# Altium schematic sheet 5.04.51805A02_GDMCU.SchDoc(5.04.51805A02_GDMCU)
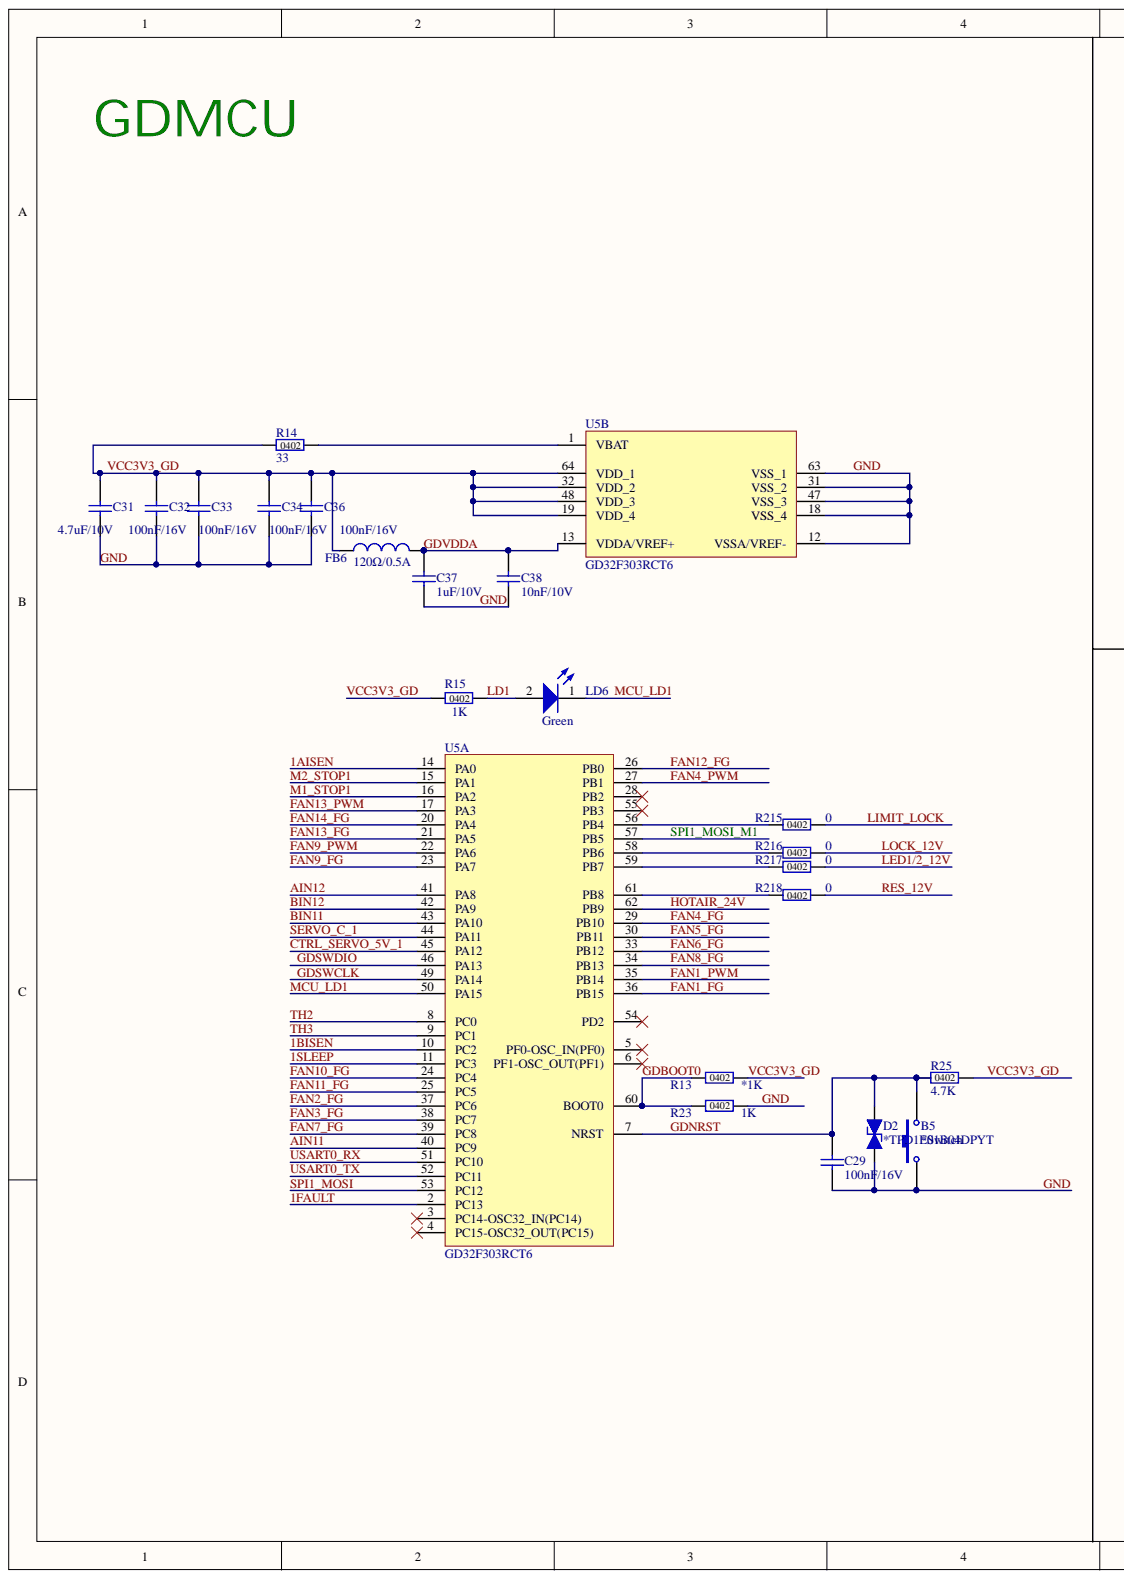
[diagram p0: 5.04.51805A02_GDMCU.SchDoc, left]
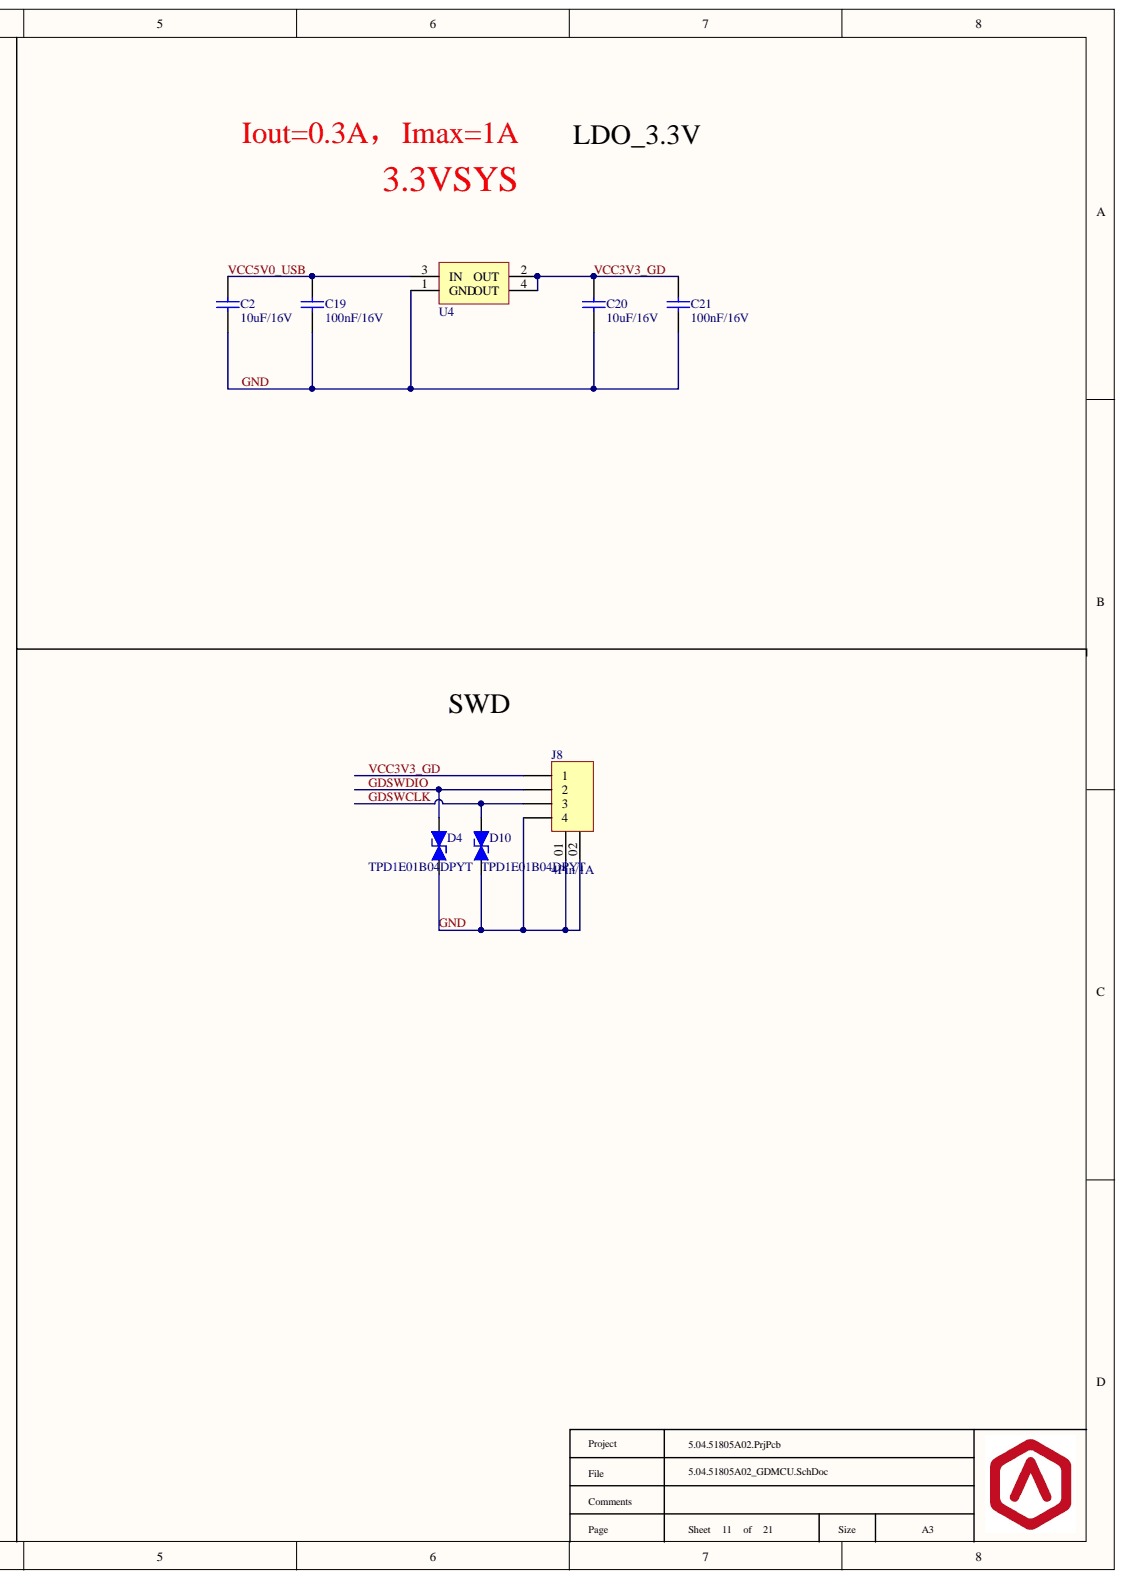
[diagram p1: 5.04.51805A02_GDMCU.SchDoc, right]

## Parts
B5  *Switch  [TS-021]
C2  10uF/16V  [C0603]
C19  100nF/16V  [C0402]
C20  10uF/16V  [C0603]
C21  100nF/16V  [C0402]
C29  100nF/16V  [C0402]
C31  4.7uF/10V  [C0402]
C32  100nF/16V  [C0402]
C33  100nF/16V  [C0402]
C34  100nF/16V  [C0402]
C36  100nF/16V  [C0402]
C37  1uF/10V  [C0402]
C38  10nF/10V  [C0402]
D2  *TPD1E01B04DPYT  [TVS_0402]
D4  TPD1E01B04DPYT  [TVS_0402]
D10  TPD1E01B04DPYT  [TVS_0402]
FB6  120Ω/0.5A  [L0402]
J8  4Pin/1A  [BM04B-GHS-TBT(LF)(SN)]
LD6  Green  [LED0603_2]
R13  *1K  [R0402]
R14  33  [R0402]
R15  1K  [R0402]
R23  1K  [R0402]
R25  4.7K  [R0402]
R215  0  [R0402]
R216  0  [R0402]
R217  0  [R0402]
R218  0  [R0402]
U4  TLV1117LV33DCYR  [SOT-223_L6.7-W3.5-P2.30-BR]  (value not drawn)
U5  GD32F303RCT6  [LQFP-64]  (parts A,B)

## Nets
1AISEN: U5.14(PA0)
1BISEN: U5.10(PC2)
1FAULT: U5.2(PC13)
1SLEEP: U5.11(PC3)
AIN11: U5.40(PC9)
AIN12: U5.41(PA8)
BIN11: U5.43(PA10)
BIN12: U5.42(PA9)
CTRL_SERVO_5V_1: U5.45(PA12)
FAN1_FG: U5.36(PB15)
FAN1_PWM: U5.35(PB14)
FAN2_FG: U5.37(PC6)
FAN3_FG: U5.38(PC7)
FAN4_FG: U5.29(PB10)
FAN4_PWM: U5.27(PB1)
FAN5_FG: U5.30(PB11)
FAN6_FG: U5.33(PB12)
FAN7_FG: U5.39(PC8)
FAN8_FG: U5.34(PB13)
FAN9_FG: U5.23(PA7)
FAN9_PWM: U5.22(PA6)
FAN10_FG: U5.24(PC4)
FAN11_FG: U5.25(PC5)
FAN12_FG: U5.26(PB0)
FAN13_FG: U5.21(PA5)
FAN13_PWM: U5.17(PA3)
FAN14_FG: U5.20(PA4)
GDBOOT0: R13.1 R23.1 U5.60(BOOT0)
GDNRST: B5.2 C29.1 D2.2 R25.1 U5.7(NRST)
GDSWCLK: D10.2 J8.3 U5.49(PA14)
GDSWDIO: D4.2 J8.2 U5.46(PA13)
GDVDDA: C37.2 C38.2 FB6.2 U5.13(VDDA/VREF+)
GND: B5.1 C2.1 C19.1 C20.1 C21.1 C29.2 C31.1 C32.1 C33.1 C34.1 C36.1 C37.1 C38.1 D2.1 D4.1 D10.1 J8.01 J8.02 J8.4 R23.2 U4.1(GND) U5.12(VSSA/VREF-) U5.18(VSS_4) U5.31(VSS_2) U5.47(VSS_3) U5.63(VSS_1)
HOTAIR_24V: U5.62(PB9)
LD1: LD6.2 R15.2
LED1/2_12V: R217.2
LIMIT_LOCK: R215.2
LOCK_12V: R216.2
M1_STOP1: U5.16(PA2)
M2_STOP1: U5.15(PA1)
MCU_LD1: LD6.1 U5.50(PA15)
RES_12V: R218.2
SERVO_C_1: U5.44(PA11)
SPI1_MOSI: U5.53(PC12)
SPI1_MOSI_M1: U5.57(PB5)
TH2: U5.8(PC0)
TH3: U5.9(PC1)
USART0_RX: U5.51(PC10)
USART0_TX: U5.52(PC11)
VCC3V3_GD: C20.2 C21.2 C31.2 C32.2 C33.2 C34.2 C36.2 FB6.1 J8.1 R13.2 R14.1 R15.1 R25.2 U4.2(OUT) U4.4(OUT) U5.19(VDD_4) U5.32(VDD_2) U5.48(VDD_3) U5.64(VDD_1)
VCC5V0_USB: C2.2 C19.2 U4.3(IN)
NetR14_2: R14.2 U5.1(VBAT)
NetR215_1: R215.1 U5.56(PB4)
NetR216_1: R216.1 U5.58(PB6)
NetR217_1: R217.1 U5.59(PB7)
NetR218_1: R218.1 U5.61(PB8)

Not connected: U5 3(PC14-OSC32_IN(PC14)) 4(PC15-OSC32_OUT(PC15)) 5(PF0-OSC_IN(PF0)) 6(PF1-OSC_OUT(PF1)) 28(PB2) 54(PD2) 55(PB3)

## Library data of the parts
B5 = B_TS-021: 开关,TS-021,DC12V50mA,30mOhm,SPST,垂直,SMT,-30°C~70°C; Manufacturer1: 东莞合智电子; Code: 57010003; Component Kind: Standard; ManufacturerPartNumber1: TS-021; Library Name: Raise3D_Switch&Optocoupler.SCHLIB
C2 C20 = C0603_10uF_16V: Ceramic Capacitor, 10uF, ±10%, 16V, -55°C~85°C, C0603; Manufacturer1: SAMSUNG; ManufacturerPartNumber1: CL10A106KO8NQNC; Code: 5172C106; Component Kind: Standard; Library Name: Raise3D_Capacitor.IntLib
C19 C21 C29 C32-C34 C36 = C0402_100nF_16V: Ceramic Capacitor, 100nF, ±10%, 16V, -55°C~125°C, C0402; Manufacturer1: Yageo; ManufacturerPartNumber1: CC0402KPX7R7BB104; Code: 5171C104; Component Kind: Standard; Library Name: Raise3D_Capacitor.IntLib
C31 = C0402_4.7uF_10V: Ceramic Capacitor, 4.7uF, ±20%, 10V, -55°C~85°C, C0402; Manufacturer1: MuRata; ManufacturerPartNumber1: GRM155R61A475MEAAD; Code: 5161C475; Component Kind: Standard; Library Name: Raise3D_Capacitor.IntLib
C37 = C0402_1uF_10V: Ceramic Capacitor, 1uF, ±10%, 10V, -55°C~125°C, C0402; Manufacturer1: Yageo; ManufacturerPartNumber1: CC0402KRX5R6BB105; Code: 5161C105; Component Kind: Standard; Library Name: Raise3D_Capacitor.IntLib
C38 = C0402_10nF_10V: Ceramic Capacitor,10nF,10%,10V,-55°C~125°C,C0402; Manufacturer1: Yageo; ManufacturerPartNumber1: CC0402KRX7R6BB103; Code: 5151C103; Component Kind: Standard; Library Name: Raise3D_Capacitor.IntLib
D2 D4 D10 = TVS_TPD1E01B04DPYT: TVS二极管,TPD1E01B04DPYT,3.6V,1Channel,+/-17kV,+/-15kV,X1SON-2,-40°C~125°C; Manufacturer1: TexasInstruments; Code: 53040002; Component Kind: Standard; ManufacturerPartNumber1: TPD1E01B04DPYT
  D2: Library Name: Raise3D_Diode.SCHLIB
  D4 D10: Library Name: Raise3D_Diode.IntLib
FB6 = Bead_120Ohm_0.5A: Bead,BLM15AG121SN1D,120Ohm,0.5A,25%,L0402,-55°C~125°C; Manufacturer1: Murata; Code: 52010001; Component Kind: Standard; ManufacturerPartNumber1: BLM15AG121SN1D; Library Name: Raise3D_Inductor&Bead.IntLib
J8 = JW_BM04B-GHS-TBT(LF)(SN): 线对板连接器,4PINS,Pitch1.25mm,SMT,OR,1A,象牙白,-25°C~85°C; Manufacturer1: JST; Code: 55010003; Component Kind: Standard; Manufacturer2: 健坤; ManufacturerPartNumber1: BM04B-GHS-TBT(LF)(SN); ManufacturerPartNumber2: A1253AWV-04-F1CB1-P; Library Name: Raise3D_Connector.IntLib
LD6 = LED_APT1608CGCK: LED,APT1608CGCK,Green,2.5V,TOP,LED0603,-40°C~85°C; Manufacturer1: Kingbright; Code: 53010003; Component Kind: Standard; ManufacturerPartNumber1: APT1608CGCK; Library Name: Raise3D_Diode.IntLib
R13 R15 R23 = R0402_1K: Resistor 1Kohm,5%,1/16W,R0402; Manufacturer1: Yageo; ManufacturerPartNumber1: RC0402JR-071KL; Code: 5011001K; Component Kind: Standard; Library Name: Raise3D_Resistor.IntLib
R14 = R0402_33: Resistor 33ohm,1%,1/16W,R0402; Manufacturer1: Yageo; ManufacturerPartNumber1: RC0402FR-0733RL; Code: 5001033R; Component Kind: Standard; Library Name: Raise3D_Resistor.IntLib
R25 = R0402_4.7K: Resistor 4.7Kohm,5%,1/16W,R0402; Manufacturer1: Yageo; ManufacturerPartNumber1: RC0402JR-074K7L; Code: 501104K7; Component Kind: Standard; Manufacturer2: Samsung; ManufacturerPartNumber2: RCS1005J472CS; Library Name: Raise3D_Resistor.IntLib
R215-R218 = R0402_0: Resistor 0ohm,1%,1/16W,R0402; Manufacturer1: Yageo; ManufacturerPartNumber1: RC0402FR-070RL; Code: 5001000R; Component Kind: Standard; Library Name: Raise3D_Resistor.IntLib
U4 = TLV1117LV33DCYR: LDO,5.5V/3.3V,1A,-45℃~125℃,SOT-223; Manufacturer1: TI; Code: C15578; Component Kind: Standard; Manufacturer2: JSMSEMI; ManufacturerPartNumber1: TLV1117LV33DCYR; ManufacturerPartNumber2: TLV1117LV33DCYR; Library Name: Raise3D_General.IntLib
U5 = MCU_GD32F303RCT6: MCU,ARM Cortex-M4,GD32F303RCT6,32bit,72MHz,51,48Kb,2.6V-3.6V,LQFP-64,-40°C~85°C; Manufacturer1: GD; Code: 59010012; Component Kind: Standard; ManufacturerPartNumber1: GD32F303RCT6; ManufacturerPartNumber2: 2; Library Name: Raise3D_IC.IntLib
